# Altium schematic sheet Voltage_Sensor.SchDoc
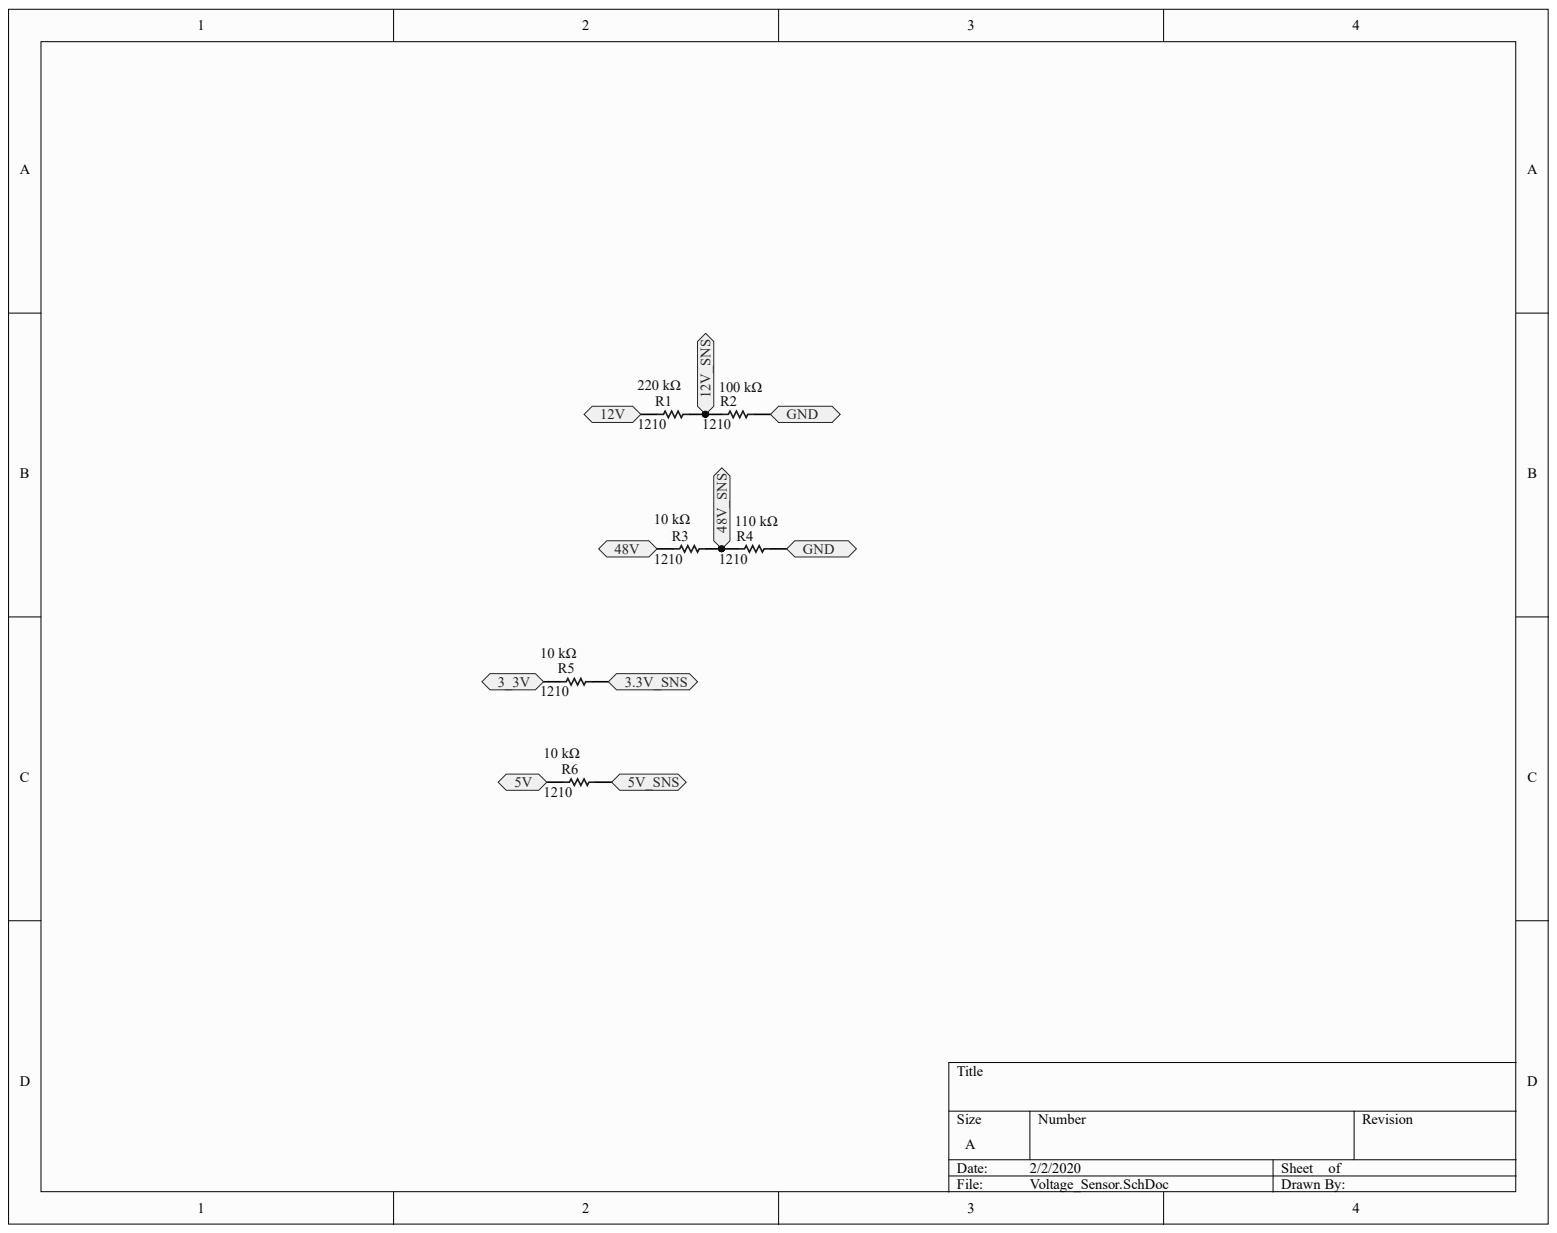
[diagram p0: Voltage_Sensor.SchDoc]

## Parts
R1  Case/Package: 1210  Resistance: 220 kΩ  [RESC3225X60X45NL20T20]  (hidden comment: Vishay Dale CRCW1210100RJNEA)
R2  Case/Package: 1210  Resistance: 100 kΩ  [RESC3225X60X45NL20T20]  (hidden comment: Vishay Dale CRCW1210100RJNEA)
R3  Case/Package: 1210  Resistance: 10 kΩ  [RESC3225X60X45NL20T20]  (hidden comment: Vishay Dale CRCW1210100RJNEA)
R4  Case/Package: 1210  Resistance: 110 kΩ  [RESC3225X60X45NL20T20]  (hidden comment: Vishay Dale CRCW1210100RJNEA)
R5  Case/Package: 1210  Resistance: 10 kΩ  [RESC3225X60X45NL20T20]  (hidden comment: Vishay Dale CRCW1210100RJNEA)
R6  Case/Package: 1210  Resistance: 10 kΩ  [RESC3225X60X45NL20T20]  (hidden comment: Vishay Dale CRCW1210100RJNEA)

## Ports
3.3V_SNS, 3_3V, 5V, 5V_SNS, 12V, 12V_SNS, 48V, 48V_SNS, GND

## Nets
3.3V_SNS: R5.2 ; port 3.3V_SNS
3_3V: R5.1 ; port 3_3V
5V: R6.1 ; port 5V
5V_SNS: R6.2 ; port 5V_SNS
12V: R1.1 ; port 12V
12V_SNS: R1.2 R2.1 ; port 12V_SNS
48V: R3.1 ; port 48V
48V_SNS: R3.2 R4.1 ; port 48V_SNS
GND: R2.2 R4.2 ; port GND

## Library data of the parts
R1-R6 = CMP-1860034-2: RES SMD 100 OHM 5% 1/2W 1210; Lead-Free Status: Lead Free; Power Rating: 0.5 W; Voltage Rating (DC): 200.0 V; Component Kind: Standard; Library Name: CommunityVault; Manufacturer Part Number: CRCW1210100RJNEA; Mounting Style: Surface Mount; Number of Pins: 2; OctopartID: 25beeeeb7039fa79; Octopart Url: https://octopart.com/crcw1210100rjnea-vishay-39809249; Packaging: Cut Tape (CT); REACH SVHC Compliance: No SVHC; Resistance Tolerance: ±5%; RoHS: Compliant; Size-Height: 0.00055 m; Size-Length: 0.0032 m; Size-Width: 0.0025 m
